# Altium schematic sheet ADC.SchDoc
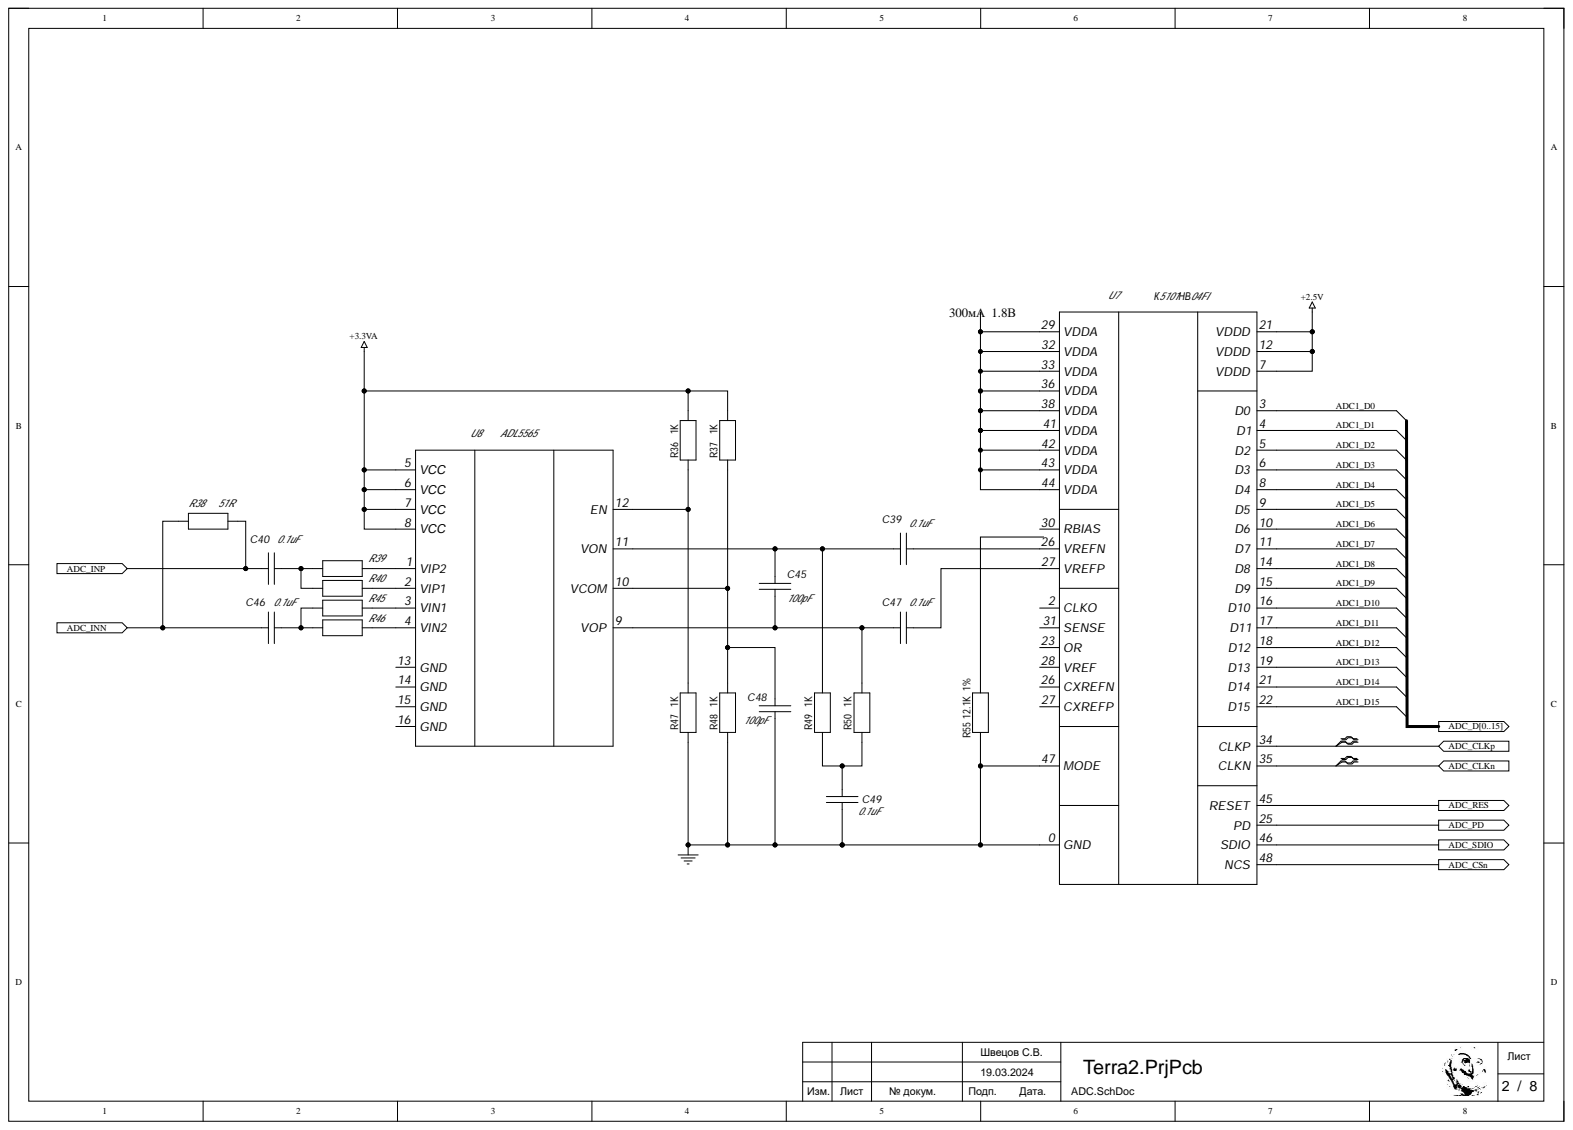
[diagram p0: ADC.SchDoc]

## Parts
C39  value 0.1uF  (hidden comment: Cap)
C40  value 0.1uF  (hidden comment: Cap)
C45  value 100pF  (hidden comment: Cap)
C46  value 0.1uF  (hidden comment: Cap)
C47  value 0.1uF  (hidden comment: Cap)
C48  value 100pF  (hidden comment: Cap)
C49  value 0.1uF  (hidden comment: Cap)
R36  value 1K  (hidden comment: Res2)
R37  value 1K  (hidden comment: Res2)
R38  value 51R  (hidden comment: Res2)
R39  Res2  (value not drawn)
R40  Res2  (value not drawn)
R45  Res2  (value not drawn)
R46  Res2  (value not drawn)
R47  value 1K  (hidden comment: Res2)
R48  value 1K  (hidden comment: Res2)
R49  value 1K  (hidden comment: Res2)
R50  value 1K  (hidden comment: Res2)
R55  value 12.1K  Precision: 1%  (hidden comment: Res2)
U7  К5101НВ04FI
U8  ADL5565

## Ports
ADC_CLKn, ADC_CLKp, ADC_CSn, ADC_D0, ADC_D1, ADC_D2, ADC_D3, ADC_D4, ADC_D5, ADC_D6, ADC_D7, ADC_D8, ADC_D9, ADC_D10, ADC_D11, ADC_D12, ADC_D13, ADC_D14, ADC_D15, ADC_D[0..15], ADC_INN, ADC_INP, ADC_PD, ADC_RES, ADC_SDIO

## Nets
+2.5V: U7.7(VDDD) U7.12(VDDD) U7.21
+3.3VA: R36.2 R37.2 U8.5(VСС) U8.6(VСС) U8.7(VСС) U8.8(VСС)
GND: C48.1 C49.1 R47.1 R48.1 R55.1 U7.0(GND) U7.47(MODE)
ADC1_D0: U7.3(D0)
ADC1_D1: U7.4(D1)
ADC1_D2: U7.5(D2)
ADC1_D3: U7.6(D3)
ADC1_D4: U7.8(D4)
ADC1_D5: U7.9(D5)
ADC1_D6: U7.10(D6)
ADC1_D7: U7.11(D7)
ADC1_D8: U7.14(D8)
ADC1_D9: U7.15(D9)
ADC1_D10: U7.16(D10)
ADC1_D11: U7.17(D11)
ADC1_D12: U7.18(D12)
ADC1_D13: U7.19(D13)
ADC1_D14: U7.21
ADC1_D15: U7.22(D15)
ADC_CLKn: U7.35(CLKN) ; port ADC_CLKn
ADC_CLKp: U7.34(CLKP) ; port ADC_CLKp
ADC_CSn: U7.48(NCS) ; port ADC_CSn
ADC_INN: C46.1 R38.2 ; port ADC_INN
ADC_INP: C40.1 R38.1 ; port ADC_INP
ADC_PD: U7.25(PD) ; port ADC_PD
ADC_RES: U7.45(RESET) ; port ADC_RES
ADC_SDIO: U7.46(SDIO) ; port ADC_SDIO
NetC39_1: C39.1 C45.2 R49.2 U8.11(VON)
NetC39_2: C39.2 U7.26
NetC40_2: C40.2 R39.2 R40.2
NetC45_1: C45.1 C47.1 R50.2 U8.9(VOP)
NetC46_2: C46.2 R45.2 R46.2
NetC47_2: C47.2 U7.27
NetC48_2: C48.2 R37.1 R48.2 U8.10(VCOM)
NetC49_2: C49.2 R49.1 R50.1
NetR36_1: R36.1 R47.2 U8.12(EN)
NetR39_1: R39.1 U8.1(VIP2)
NetR40_1: R40.1 U8.2(VIP1)
NetR45_1: R45.1 U8.3(VIN1)
NetR46_1: R46.1 U8.4(VIN2)
NetU7_29: U7.29(VDDA) U7.32(VDDA) U7.33(VDDA) U7.36(VDDA) U7.38(VDDA) U7.41(VDDA) U7.42(VDDA) U7.43(VDDA) U7.44(VDDA)
N00001_0: ; port ADC_D[0..15]
N00001_1: ; port ADC_D[0..15]
N00001_2: ; port ADC_D[0..15]
N00001_3: ; port ADC_D[0..15]
N00001_4: ; port ADC_D[0..15]
N00001_5: ; port ADC_D[0..15]
N00001_6: ; port ADC_D[0..15]
N00001_7: ; port ADC_D[0..15]
N00001_8: ; port ADC_D[0..15]
N00001_9: ; port ADC_D[0..15]
N00001_10: ; port ADC_D[0..15]
N00001_11: ; port ADC_D[0..15]
N00001_12: ; port ADC_D[0..15]
N00001_13: ; port ADC_D[0..15]
N00001_14: ; port ADC_D[0..15]
N00001_15: ; port ADC_D[0..15]

Not connected: R55 2; U7 2(CLKO) 23(OR) 28(VREF) 30(RBIAS) 31(SENSE); U8 13(GND) 14(GND) 15(GND) 16(GND)

## Library data of the parts
C39 C40 C45-C49 = Cap: Конденсатор; Component Kind: Standard; PackageReference: 0603; Signal Integrity: Cap; Library Name: Terra.SCHLIB
R36-R38 R47-R50 R55 = Res2: Резистор; Component Kind: Standard; PackageReference: 0603; Signal Integrity: Res; Library Name: Terra.SCHLIB
R39 R40 R45 R46 = Res2: Резистор; Value: 0R; Component Kind: Standard; PackageReference: 0603; Signal Integrity: Res; Library Name: Terra.SCHLIB
U7 = К5101НВ04FI: АЦП 95мГц 14бит; Parameter 1: VQFN48L; Component Kind: Standard; Library Name: common.SCHLIB
U8 = DS90LV047A: 6 GHz, Ultrahigh Dynamic Range  Differential Amplifier; Component Kind: Standard; Library Name: common.SCHLIB; PackageReference: SO8; Value: ADL5565
